# Altium schematic sheet Sheet1.SchDoc
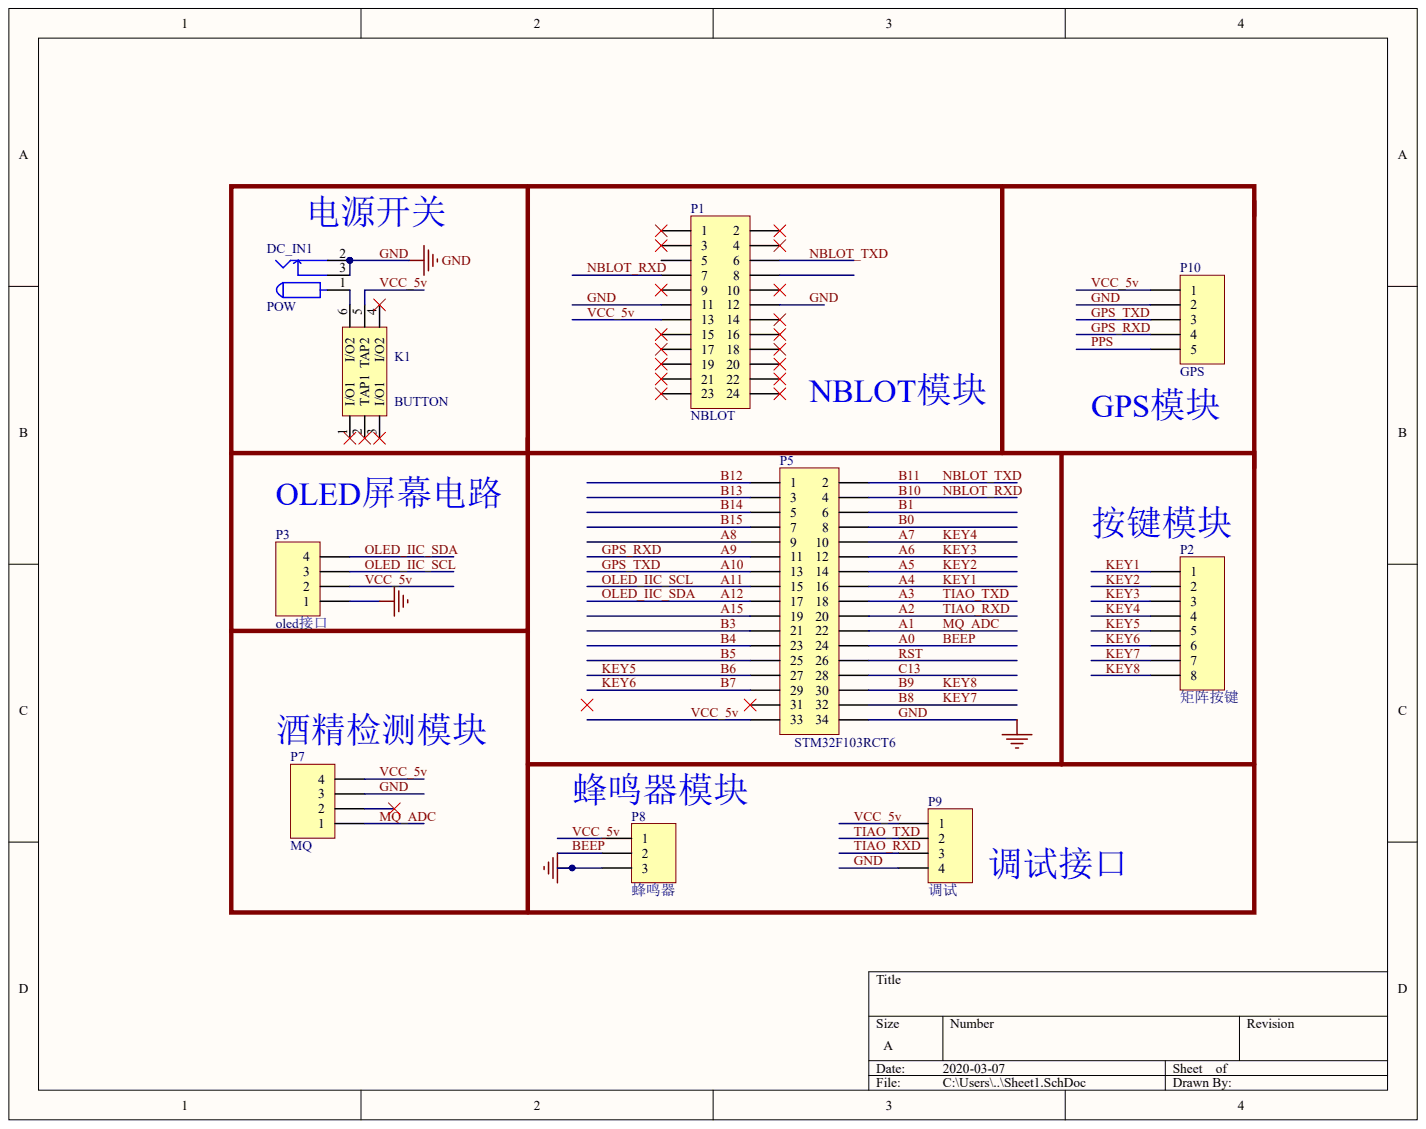
[diagram p0: Sheet1.SchDoc]

## Parts
DC_IN1  POW
K1  BUTTON
P1  NBLOT
P2  矩阵按键
P3  oled接口
P5  STM32F103RCT6
P7  MQ
P8  蜂鸣器
P9  调试
P10  GPS

## Nets
A0: P5.24 P8.2
A1: P5.22 P7.1
A2: P5.20 P9.3
A3: P5.18 P9.2
A4: P2.1 P5.16
A5: P2.2 P5.14
A6: P2.3 P5.12
A7: P2.4 P5.10
A8: P5.9
A9: P5.11 P10.4
A10: P5.13 P10.3
A11: P3.3 P5.15
A12: P3.4 P5.17
A15: P5.19
B0: P5.8
B1: P5.6
B3: P5.21
B4: P5.23
B5: P5.25
B6: P2.5 P5.27
B7: P2.6 P5.29
B8: P2.7 P5.32
B9: P2.8 P5.30
B10: P1.7 P5.4
B11: P1.6 P5.2
B12: P5.1
B13: P5.3
B14: P5.5
B15: P5.7
C13: P5.28
GND: DC_IN1.2 DC_IN1.3 P1.11 P1.12 P3.1 P5.34 P7.3 P8.3 P9.4 P10.2
PPS: P10.5
RST: P5.26
VCC_5V: K1.5(TAP2) P1.13 P3.2 P5.33 P7.4 P8.1 P9.1 P10.1
NetDC_IN1_1: DC_IN1.1 K1.6(I/O2)

Not connected: K1 1(I/O1) 2(TAP1) 3(I/O1) 4(I/O2); P1 1 2 3 4 5 8 9 10 14 15 16 17 18 19 20 21 22 23 24; P5 31; P7 2

## Library data of the parts
DC_IN1 K1 P1-P3 P5 P7-P10 = (no symbol name)
  P1-P3 P5 P7-P10: LatestRevisionDate: 17-Jul-2002; LatestRevisionNote: Re-released for DXP Platform.; Publisher: Altium Limited
